# Altium schematic sheet Connections.SchDoc(Connections)
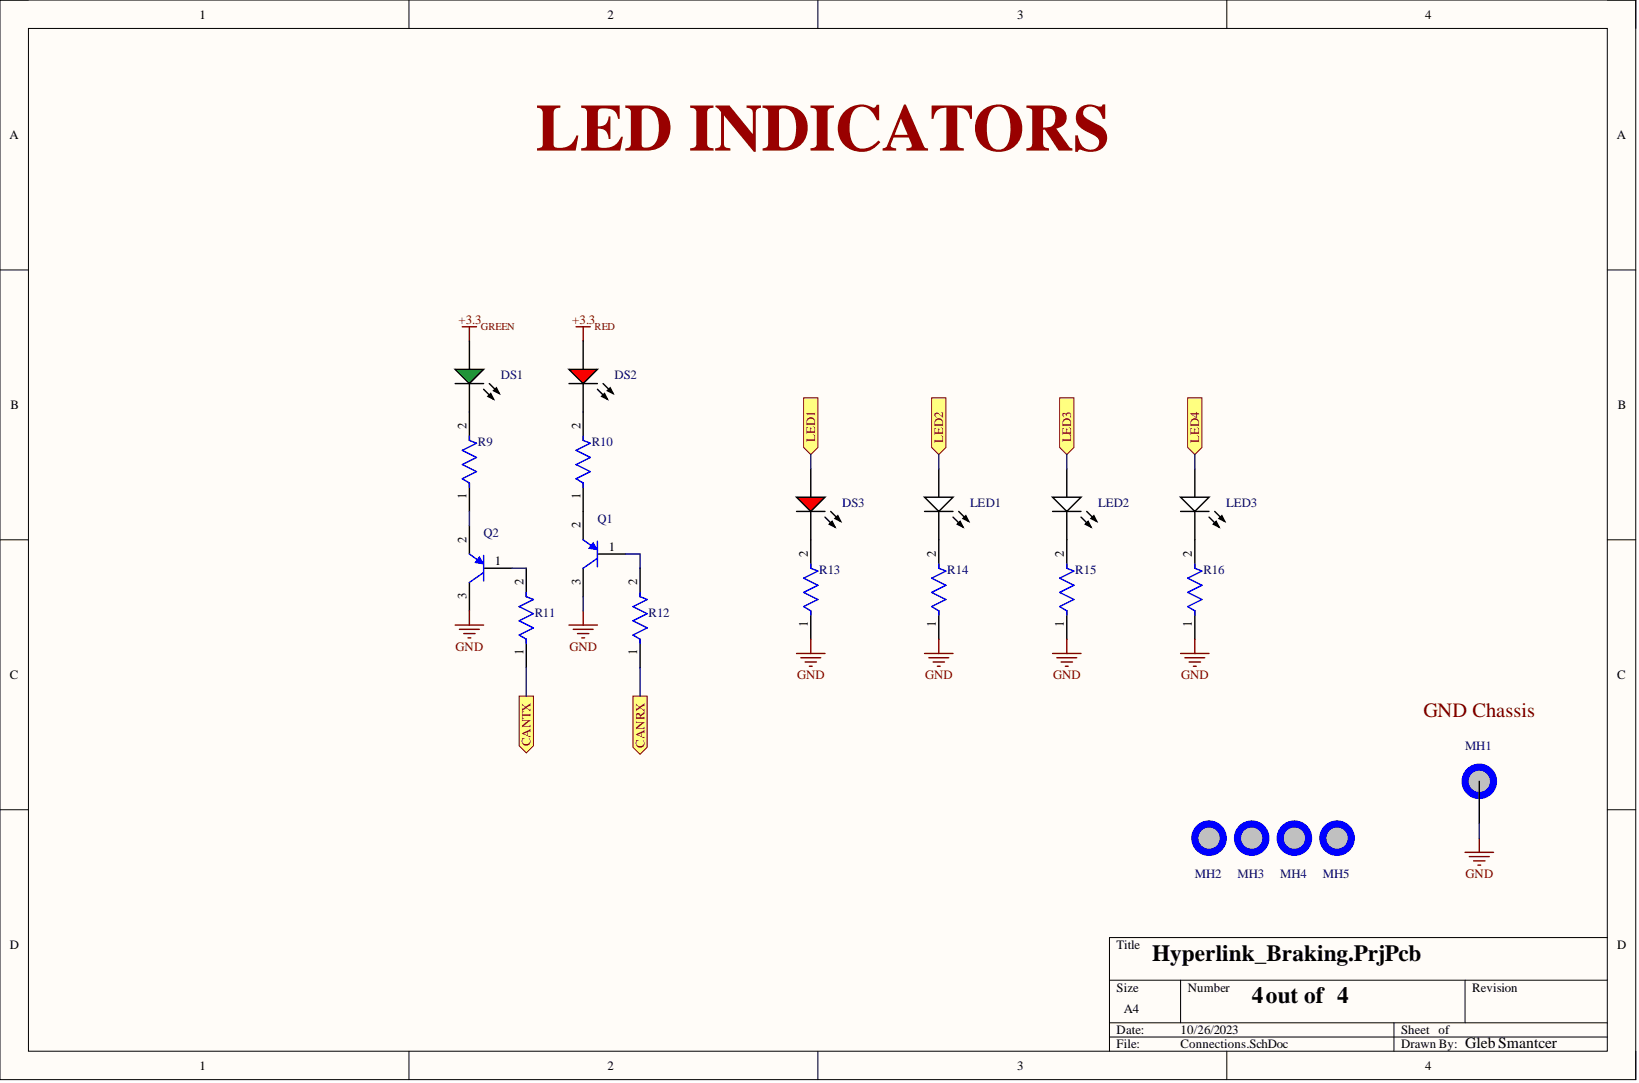
[diagram p0: Connections.SchDoc]

## Parts
DS1  LTST-C190GKT  (value not drawn)
DS2  LTST-C190KRKT  (value not drawn)
DS3  LTST-C190KRKT  (value not drawn)
LED1  HSMW-C191  (value not drawn)
LED2  HSMW-C191  (value not drawn)
LED3  HSMW-C191  (value not drawn)
MH1  MH_7.4MMC_3.2MMD  (value not drawn)  (no BOM)
MH2  MH_7.4MMNC_3.2MMNC  (value not drawn)  (no BOM)
MH3  MH_7.4MMNC_3.2MMNC  (value not drawn)  (no BOM)
MH4  MH_7.4MMNC_3.2MMNC  (value not drawn)  (no BOM)
MH5  MH_7.4MMNC_3.2MMNC  (value not drawn)  (no BOM)
Q1  BC807-25LT1G  (value not drawn)
Q2  BC807-25LT1G  (value not drawn)
R9  CRCW06031K00FKEA  (value not drawn)
R10  CRCW06031K00FKEA  (value not drawn)
R11  CRCW06032K20FKEAHP  (value not drawn)
R12  CRCW06032K20FKEAHP  (value not drawn)
R13  CRCW06031K00FKEA  (value not drawn)
R14  CRCW06031K00FKEA  (value not drawn)
R15  CRCW06031K00FKEA  (value not drawn)
R16  CRCW06031K00FKEA  (value not drawn)

## Ports
CANRX, CANTX, LED1, LED2, LED3, LED4

## Nets
+3.3: DS1.1 DS2.1
GND: MH1.1 Q1.3 Q2.3 R13.1 R14.1 R15.1 R16.1
CANRX: R12.1 ; port CANRX
CANTX: R11.1 ; port CANTX
LED1: DS3.1 ; port LED1
LED2: LED1.1 ; port LED2
LED3: LED2.1 ; port LED3
LED4: LED3.1 ; port LED4
NetDS1_2: DS1.2 R9.2
NetDS2_2: DS2.2 R10.2
NetDS3_2: DS3.2 R13.2
NetLED1_2: LED1.2 R14.2
NetLED2_2: LED2.2 R15.2
NetLED3_2: LED3.2 R16.2
NetQ1_1: Q1.1 R12.2
NetQ1_2: Q1.2 R10.1
NetQ2_1: Q2.1 R11.2
NetQ2_2: Q2.2 R9.1

## Library data of the parts
DS1 = CMP-007-00022-1: LED Uni-Color Green, 100 mW, 30 mA, -55 to 85 degC, 2-Pin SMD, RoHS, Tape and Reel; Manufacturer: Lite-On; Forward Current: 20mA; Forward Voltage: 2.1V; Lens Style: Clear; Luminous Intensity: 6mcd; Power: 100mW; Viewing Angle: 130degrees; Case/Package: 0603; Colour: Green; Component Kind: Standard; Datasheet URL: http://datasheet.octopart.com/LTST-C190GKT-Lite-On-datasheet-158530.pdf; Datasheet Version: Dec-06; Illumination Colour: Green; JLC: C72043; Manufacturer URL: http://www.us.liteon.com/; Max Operating Temperature: 85°C; Min Operating Temperature: -55°C; Mounting Technology: SM; Nominal Vf: 2.1V; Package Description: 2-Pin Surface Mount Device, Body 1.6 x 0.8 mm; Package Quantity: 3000; Package Reference: C190GKT; Packaging: TapeandReel; Pins: 2; RoHS Compliant: True; Library Name: QMUL Hyperlink
DS2 DS3 = CMP-007-00024-1: LED Uni-Color Red, 62.5 mW, 25 mA, -30 to 85 degC, 2-Pin SMD, RoHS, Tape and Reel; Manufacturer: Lite-On; Forward Current: 20mA; Forward Voltage: 2V; Lens Style: Diffused; Luminous Intensity: 54mcd; Power: 75mW; Viewing Angle: 130degrees; Case/Package: 0603; Colour: Red; Component Kind: Standard; Datasheet URL: http://optoelectronics.liteon.com/upload/download/DS-22-99-0151/S_110_LTST-C190KRKT.pdf; Datasheet Version: Rev. F, 05/2013; Illumination Colour: Red; JLC: C72044; Manufacturer URL: http://www.us.liteon.com/; Max Operating Temperature: 85°C; Min Operating Temperature: -55°C; Mounting Technology: SM; Nominal Vf: 2V; Package Description: 2-Pin Surface Mount Device, Body 1.6 x 0.8 mm; Package Quantity: 3000; Package Reference: C191KRKT; Packaging: TapeandReel; Pins: 2; RoHS Compliant: True; Library Name: QMUL Hyperlink
LED1-LED3 = CMP-1744-00001-1: LED Uni-Color RED, 78 mW, 20 mA, -40 to 85 degC, 2-Pin SMD, RoHS, Tape and Reel; Current Rating: 300 mA; Component Kind: Standard; ComponentLink1Description: Manufacturer URL; ComponentLink1URL: http://www.avagotech.com/; ComponentLink2Description: Datasheet; ComponentLink2URL: http://docs.avagotech.com/docs/AV02-0186EN; Contact Finish: Silver; Contact Material: Copper; Contact Plating: Silver; DatasheetVersion: May-10; Height: 12 mm; JLC: C72044; Length: 16 mm; Library Name: Altium Content Vault; Max Operating Temperature: 85 degC; Min Operating Temperature: -20 degC; Mount: Through Hole; Package Quantity: 560; PackageDescription: 2-Pin Surface Mount Device, Body 1.6 x 0.8 mm; PackageReference: HSMW-C191; Packaging: Bulk; Radiation Hardening: No; REACH SVHC: Unknown; RoHSCompliant: true; Width: 12 mm
MH1 = MH_7.4MMC_3.2MMD: Mounting Hole 7.4mm Diameter, 3.2mm Drill; Library Name: Hyperlink_Int_Library.IntLib
MH2-MH5 = MH_7.4MMNC_3.2MMNC: Hole 7.4mm Diameter (No Copper), 3.2mm Drill NPTH; Library Name: Hyperlink_Int_Library.IntLib
Q1 Q2 = CMP-1048-00691-1: General Purpose Transistor, PNP Silicon, 3-Pin SOT-23, Pb-Free, Tape and Reel; Manufacturer: On Semiconductor; fT Min (MHz): 100; IC Continuous (A): -0.5; Component Kind: Standard; ComponentLink1Description: Manufacturer URL; ComponentLink1URL: http://www.onsemi.com/; ComponentLink2Description: Datasheet; ComponentLink2URL: http://www.onsemi.com/pub_link/Collateral/BC807-16LT1-D.PDF; ComponentLink3Description: Package Specification; ComponentLink3URL: http://www.onsemi.cn/pub_link/Collateral/318-08.PDF; DatasheetVersion: Rev. 10; JLC: C131673; Library Name: Altium Content Vault; Mounting Technology: Surface Mount; PackageDescription: 3-Pin Small Outline Transistor, Body 2.92 x 1.3 mm, Pitch 0.95 mm; PackageReference: SOT-23-3-318-08; PackageVersion: Rev. AP, 11/2009; Packing: Tape and Reel; PartNumber: BC807-25LT1G; Polarity: PNP; RoHS: TRUE
R9 R10 R13-R16 = CMP-1666-00003-5: RES Thick Film, 1kΩ, 1%, 0.1W, 100ppm/°C, 0603; Max Power Dissipation: 100mW; Resistance: 1kR; Voltage Rating: 75V; Case Code (Imperial): 0603; Case Code (Metric): 1608; Case/Package: 0603; Component Kind: Standard; Composition: Thick Film; Contact Plating: Tin; Depth: 0.85mm; Features: Automotive AEC-Q200; Height: 0.45mm; JLC: C21190; Lead Free: Lead Free; Length: 1.55mm; Library Name: Altium Content Vault; Max Operating Temperature: 155°C; Military Standard: Not; Min Operating Temperature: -55°C; Mount: Surface Mount; Number of Pins: 2; Number of Terminations: 2; Package Quantity: 10; Packaging: Tape and Reel; Power Rating: 100mW; Radiation Hardening: No; Temperature Coefficient: 100ppm/°C; Termination: SMD/SMT; Tolerance: 1%; Voltage Rating (DC): 75V; Weight: 7.1E-05oz; Width: 0.033inch
R11 R12 = CMP-02407-010809-1: RES Thick Film, 2.2kΩ, 1%, 0.33W, 100ppm/°C, 0603; Max Power Dissipation: 250mW; Resistance: 2.2kR; Voltage Rating: 75V; Case Code (Imperial): 0603; Case Code (Metric): 1608; Case/Package: 0603; Component Kind: Standard; Composition: Thick Film; Contact Plating: Tin; Depth: 0.85mm; Features: Automotive AEC-Q200; Height: 0.02inch; JLC: C4190; Lead Free: Lead Free; Length: 1.6mm; Library Name: Altium Content Vault; Max Operating Temperature: 155°C; Military Standard: Not; Min Operating Temperature: -55°C; Mount: Surface Mount; Number of Terminations: 2; Package Quantity: 1; Packaging: Tape and Reel; Power Rating: 250mW; Radiation Hardening: No; Temperature Coefficient: 100ppm/°C; Termination: Solder; Tolerance: 1%; Voltage Rating (DC): 75V; Width: 0.033inch
